# Altium schematic sheet 线圈气泵电磁阀.SchDoc(线圈气泵电磁阀)
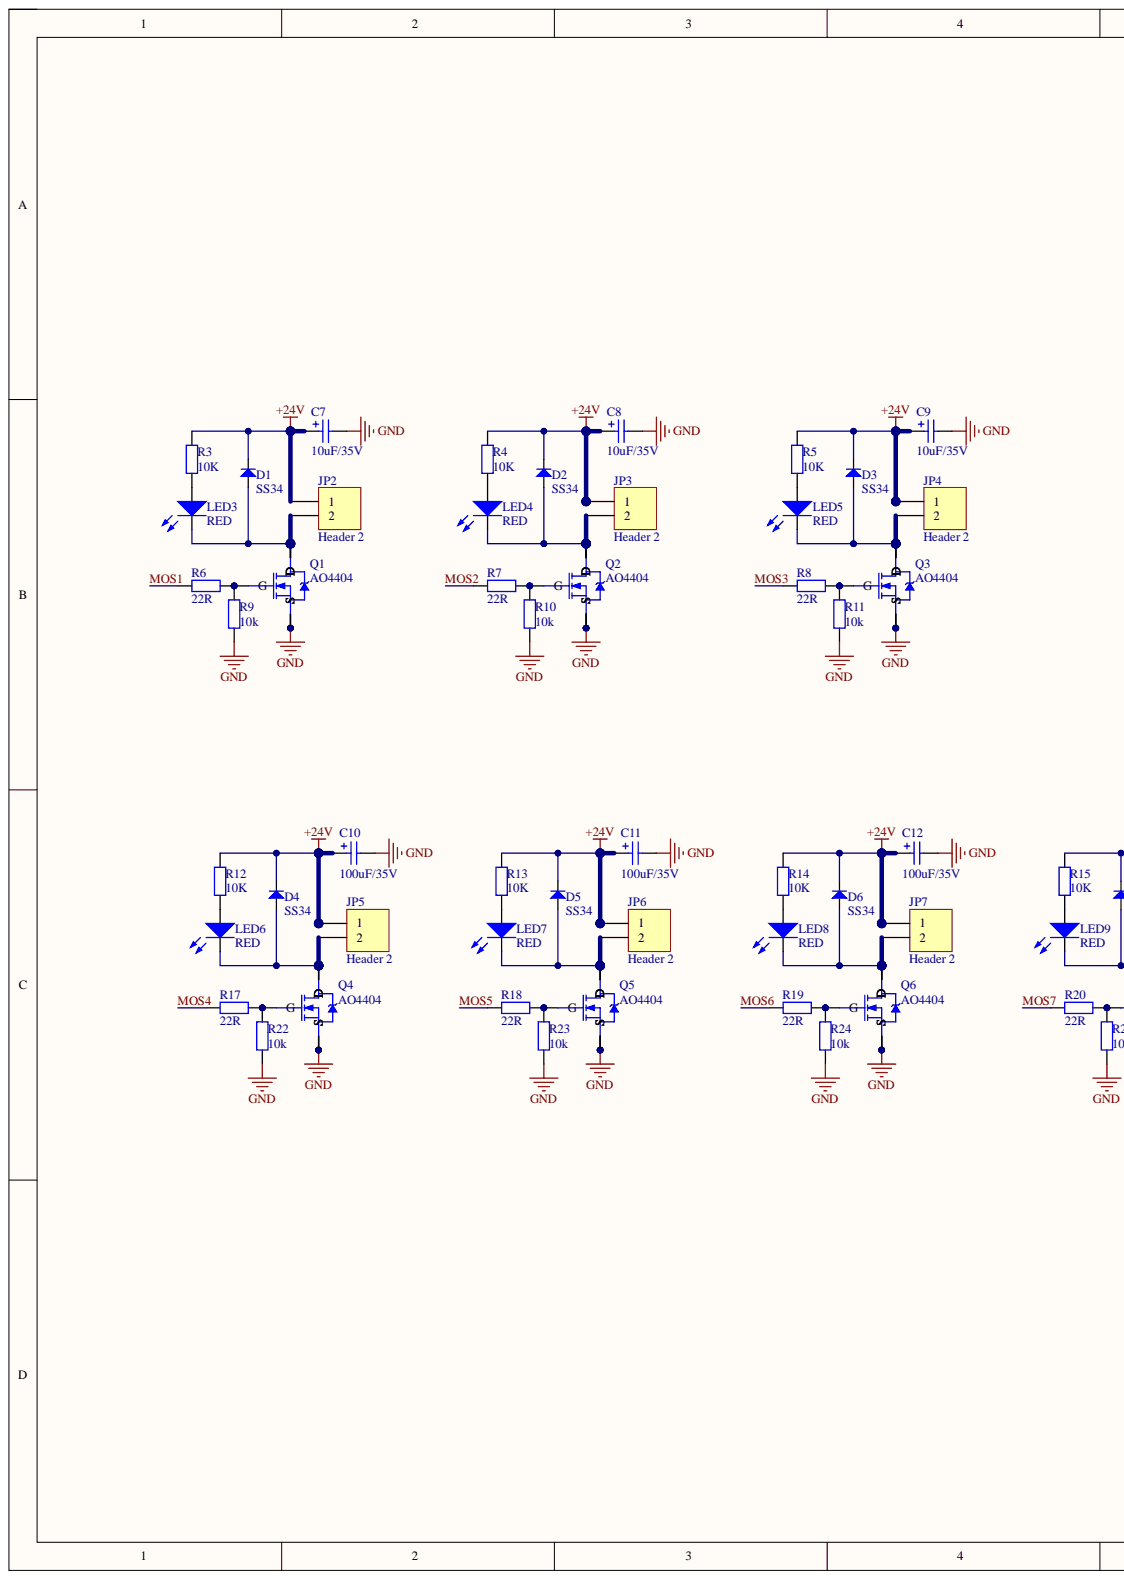
[diagram p0: 线圈气泵电磁阀.SchDoc, left]
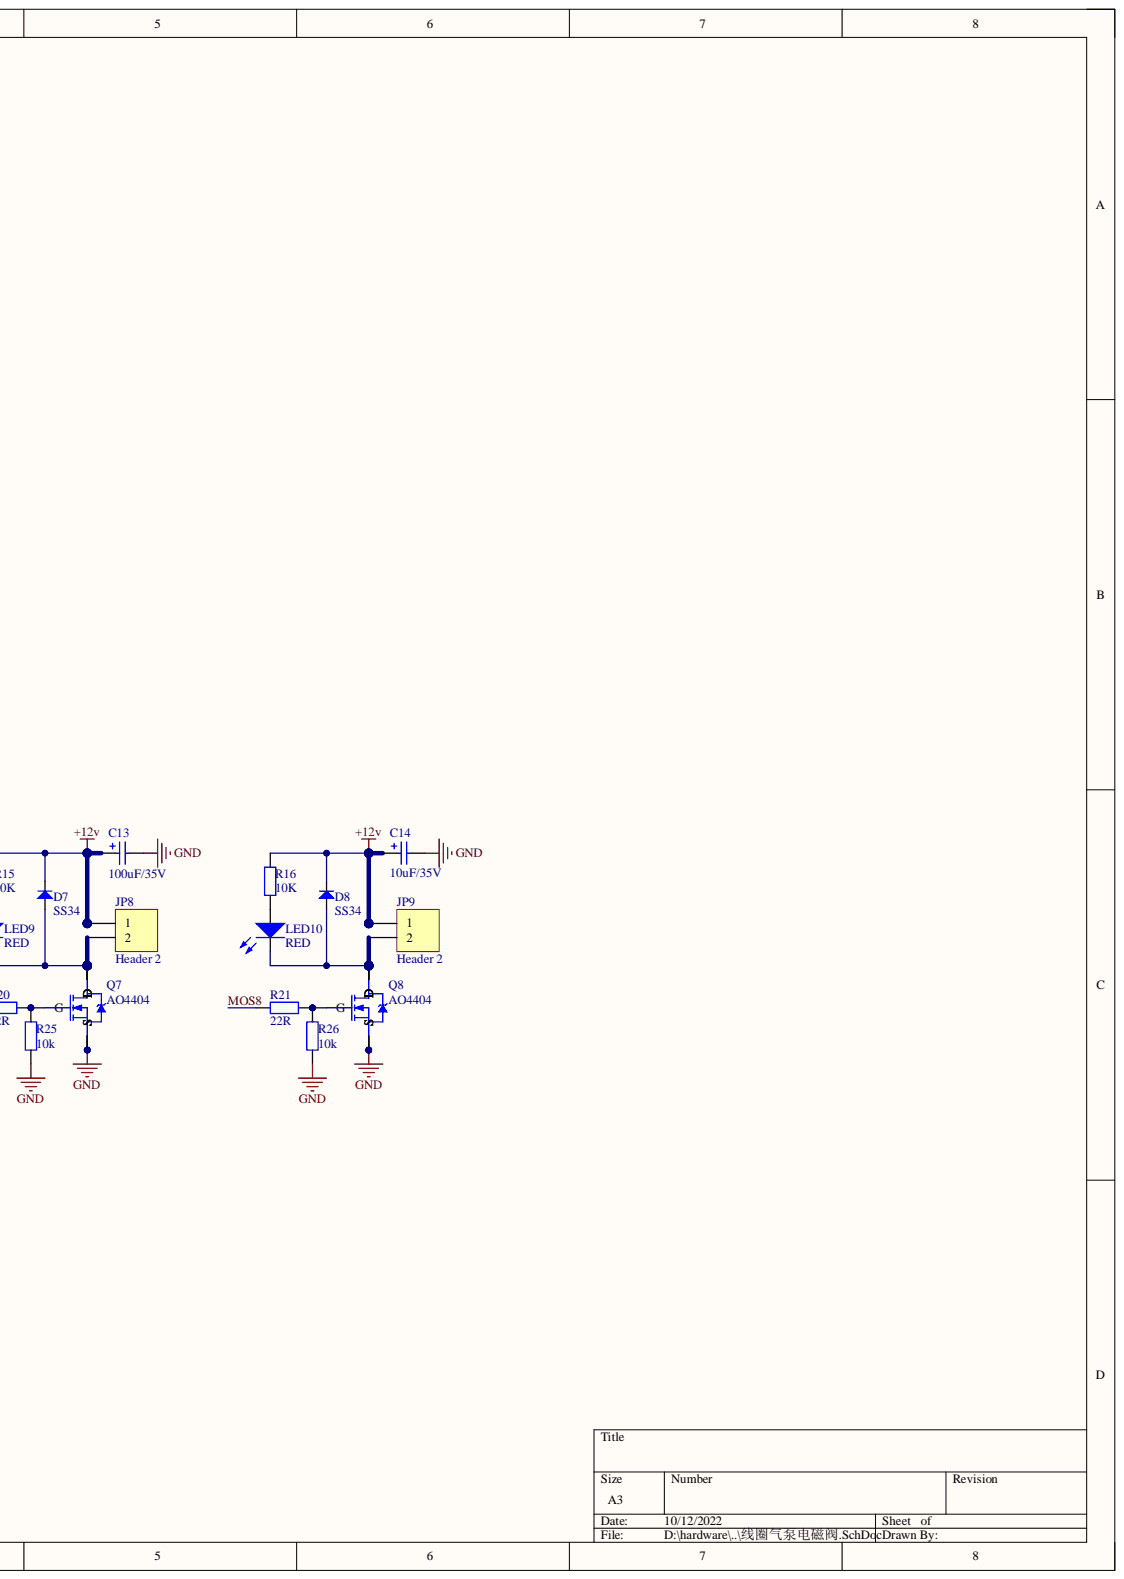
[diagram p1: 线圈气泵电磁阀.SchDoc, right]

## Parts
C7  10uF/35V  [CAP-SMD_L6.0-W3.2-R-RD]
C8  10uF/35V  [CAP-SMD_L6.0-W3.2-R-RD]
C9  10uF/35V  [CAP-SMD_L6.0-W3.2-R-RD]
C10  100uF/35V  [CAP-SMD_BD6.3-L6.6-W6.6-FD]
C11  100uF/35V  [CAP-SMD_BD6.3-L6.6-W6.6-FD]
C12  100uF/35V  [CAP-SMD_BD6.3-L6.6-W6.6-FD]
C13  100uF/35V  [CAP-SMD_BD6.3-L6.6-W6.6-FD]
C14  10uF/35V  [CAP-SMD_L6.0-W3.2-R-RD]
D1  SS34  [SMA-(DO-214AC)]
D2  SS34  [SMA-(DO-214AC)]
D3  SS34  [SMA-(DO-214AC)]
D4  SS34  [SMA-(DO-214AC)]
D5  SS34  [SMA-(DO-214AC)]
D6  SS34  [SMA-(DO-214AC)]
D7  SS34  [SMA-(DO-214AC)]
D8  SS34  [SMA-(DO-214AC)]
JP2  Header 2  [KF2EDG-2.54-2-H]
JP3  Header 2  [KF2EDG-2.54-2-H]
JP4  Header 2  [KF2EDG-2.54-2-H]
JP5  Header 2  [KF2EDG-2.54-2-H]
JP6  Header 2  [KF2EDG-2.54-2-H]
JP7  Header 2  [KF2EDG-2.54-2-H]
JP8  Header 2  [KF2EDG-2.54-2-H]
JP9  Header 2  [KF2EDG-2.54-2-H]
LED3  RED  [0805d_red]
LED4  RED  [0805d_red]
LED5  RED  [0805d_red]
LED6  RED  [0805d_red]
LED7  RED  [0805d_red]
LED8  RED  [0805d_red]
LED9  RED  [0805d_red]
LED10  RED  [0805d_red]
Q1  AO4404  [SOIC127P600X175-8N]
Q2  AO4404  [SOIC127P600X175-8N]
Q3  AO4404  [SOIC127P600X175-8N]
Q4  AO4404  [SOIC127P600X175-8N]
Q5  AO4404  [SOIC127P600X175-8N]
Q6  AO4404  [SOIC127P600X175-8N]
Q7  AO4404  [SOIC127P600X175-8N]
Q8  AO4404  [SOIC127P600X175-8N]
R3  10K  [0805r]
R4  10K  [0805r]
R5  10K  [0805r]
R6  22R  [0805r]
R7  22R  [0805r]
R8  22R  [0805r]
R9  10k  [0805r]
R10  10k  [0805r]
R11  10k  [0805r]
R12  10K  [0805r]
R13  10K  [0805r]
R14  10K  [0805r]
R15  10K  [0805r]
R16  10K  [0805r]
R17  22R  [0805r]
R18  22R  [0805r]
R19  22R  [0805r]
R20  22R  [0805r]
R21  22R  [0805r]
R22  10k  [0805r]
R23  10k  [0805r]
R24  10k  [0805r]
R25  10k  [0805r]
R26  10k  [0805r]

## Nets
+12v: C13.1 C14.1 D7.2 D8.2 JP8.1 JP9.1 R15.1 R16.1
+24V: C7.1 C8.1 C9.1 C10.1 C11.1 C12.1 D1.2 D2.2 D3.2 D4.2 D5.2 D6.2 JP2.1 JP3.1 JP4.1 JP5.1 JP6.1 JP7.1 R3.1 R4.1 R5.1 R12.1 R13.1 R14.1
GND: C7.2 C8.2 C9.2 C10.2 C11.2 C12.2 C13.2 C14.2 Q1.1(S) Q1.2 Q1.3 Q2.1(S) Q2.2 Q2.3 Q3.1(S) Q3.2 Q3.3 Q4.1(S) Q4.2 Q4.3 Q5.1(S) Q5.2 Q5.3 Q6.1(S) Q6.2 Q6.3 Q7.1(S) Q7.2 Q7.3 Q8.1(S) Q8.2 Q8.3 R9.1 R10.1 R11.1 R22.1 R23.1 R24.1 R25.1 R26.1
MOS1: R6.2
MOS2: R7.2
MOS3: R8.2
MOS4: R17.2
MOS5: R18.2
MOS6: R19.2
MOS7: R20.2
MOS8: R21.2
NetD1_1: D1.1 JP2.2 LED3.2 Q1.5(D) Q1.6 Q1.7 Q1.8
NetD2_1: D2.1 JP3.2 LED4.2 Q2.5(D) Q2.6 Q2.7 Q2.8
NetD3_1: D3.1 JP4.2 LED5.2 Q3.5(D) Q3.6 Q3.7 Q3.8
NetD4_1: D4.1 JP5.2 LED6.2 Q4.5(D) Q4.6 Q4.7 Q4.8
NetD5_1: D5.1 JP6.2 LED7.2 Q5.5(D) Q5.6 Q5.7 Q5.8
NetD6_1: D6.1 JP7.2 LED8.2 Q6.5(D) Q6.6 Q6.7 Q6.8
NetD7_1: D7.1 JP8.2 LED9.2 Q7.5(D) Q7.6 Q7.7 Q7.8
NetD8_1: D8.1 JP9.2 LED10.2 Q8.5(D) Q8.6 Q8.7 Q8.8
NetLED3_1: LED3.1 R3.2
NetLED4_1: LED4.1 R4.2
NetLED5_1: LED5.1 R5.2
NetLED6_1: LED6.1 R12.2
NetLED7_1: LED7.1 R13.2
NetLED8_1: LED8.1 R14.2
NetLED9_1: LED9.1 R15.2
NetLED10_1: LED10.1 R16.2
NetQ1_4: Q1.4(G) R6.1 R9.2
NetQ2_4: Q2.4(G) R7.1 R10.2
NetQ3_4: Q3.4(G) R8.1 R11.2
NetQ4_4: Q4.4(G) R17.1 R22.2
NetQ5_4: Q5.4(G) R18.1 R23.2
NetQ6_4: Q6.4(G) R19.1 R24.2
NetQ7_4: Q7.4(G) R20.1 R25.2
NetQ8_4: Q8.4(G) R21.1 R26.2

## Library data of the parts
C7-C9 C14 = Cap tan: 钽电容; Component Kind: Standard; LatestRevisionDate: 17-Jul-2002; LatestRevisionNote: Re-released for DXP Platform.; Library Name: 常用.SchLib; PackageDescription: Polarized Capacitor (Radial); 2 Leads; PackageReference: RB7.6-15; Signal Integrity: Cap Pol; Simulation: CAP; Publisher: Altium Limited
C10-C13 = Cap Pol1: 有极性定值电容器; Component Kind: Standard; LatestRevisionDate: 17-Jul-2002; LatestRevisionNote: Re-released for DXP Platform.; Library Name: 常用.SchLib; PackageDescription: Polarized Capacitor (Radial); 2 Leads; PackageReference: RB7.6-15; Signal Integrity: Cap Pol; Simulation: CAP; Publisher: Altium Limited
D1-D8 = Diode: Code_JEDEC: DO-204-AL; Component Kind: Standard; ComponentLink1Description: Manufacturer Link; ComponentLink1URL: http://www.fairchildsemi.com/; ComponentLink2Description: Datasheet; ComponentLink2URL: http://www.fairchildsemi.com/ds/1N%2F1N4001.pdf; DatasheetVersion: 1998; LatestRevisionDate: 17-Jul-2002; LatestRevisionNote: Re-released for DXP Platform.; Library Name: 常用.SchLib; PackageDescription: Diode, Axial; Body 5.2 x 2.7 mm (LxDia. max), Lead Dia. 0.86 mm (max); PackageReference: DO-41; PackageVersion: Aug-1999; Published: 8-Jun-2000; Signal Integrity: 1N4007; Simulation: 1N4007; Publisher: Altium Limited
JP2-JP9 = Header 2: Header, 2-Pin; Component Kind: Standard; LatestRevisionDate: 17-Jul-2002; LatestRevisionNote: Re-released for DXP Platform.; Signal Integrity: Connector; Library Name: 接插头.SchLib; Publisher: Altium Limited
LED3-LED10 = LED0: 普通LED; Component Kind: Standard; LatestRevisionDate: 08-Jul-2005; LatestRevisionNote: Mech Layer 1 removed.; Library Name: 常用.SchLib; PackageDescription: LED; 2 Leads; PackageReference: LED-0; Simulation: LED0; Value: RED; Publisher: Altium Limited
Q1-Q8 = NMOS_AO4404: 30V@8.5A.24毫欧; Component Kind: Standard; Library Name: 常用.SchLib
R3-R26 = Res2: 定值电阻; Component Kind: Standard; LatestRevisionDate: 17-Jul-2002; LatestRevisionNote: Re-released for DXP Platform.; Library Name: 常用.SchLib; PackageDescription: Axial Device, Thru-Hole; 2 Leads; 0.4 in Pin Spacing; PackageReference: AXIAL-0.4; Published: 8-Jun-2000; Signal Integrity: Res; Simulation: RESISTOR; Publisher: Altium Limited
